# Altium schematic sheet LedBoard.SchDoc
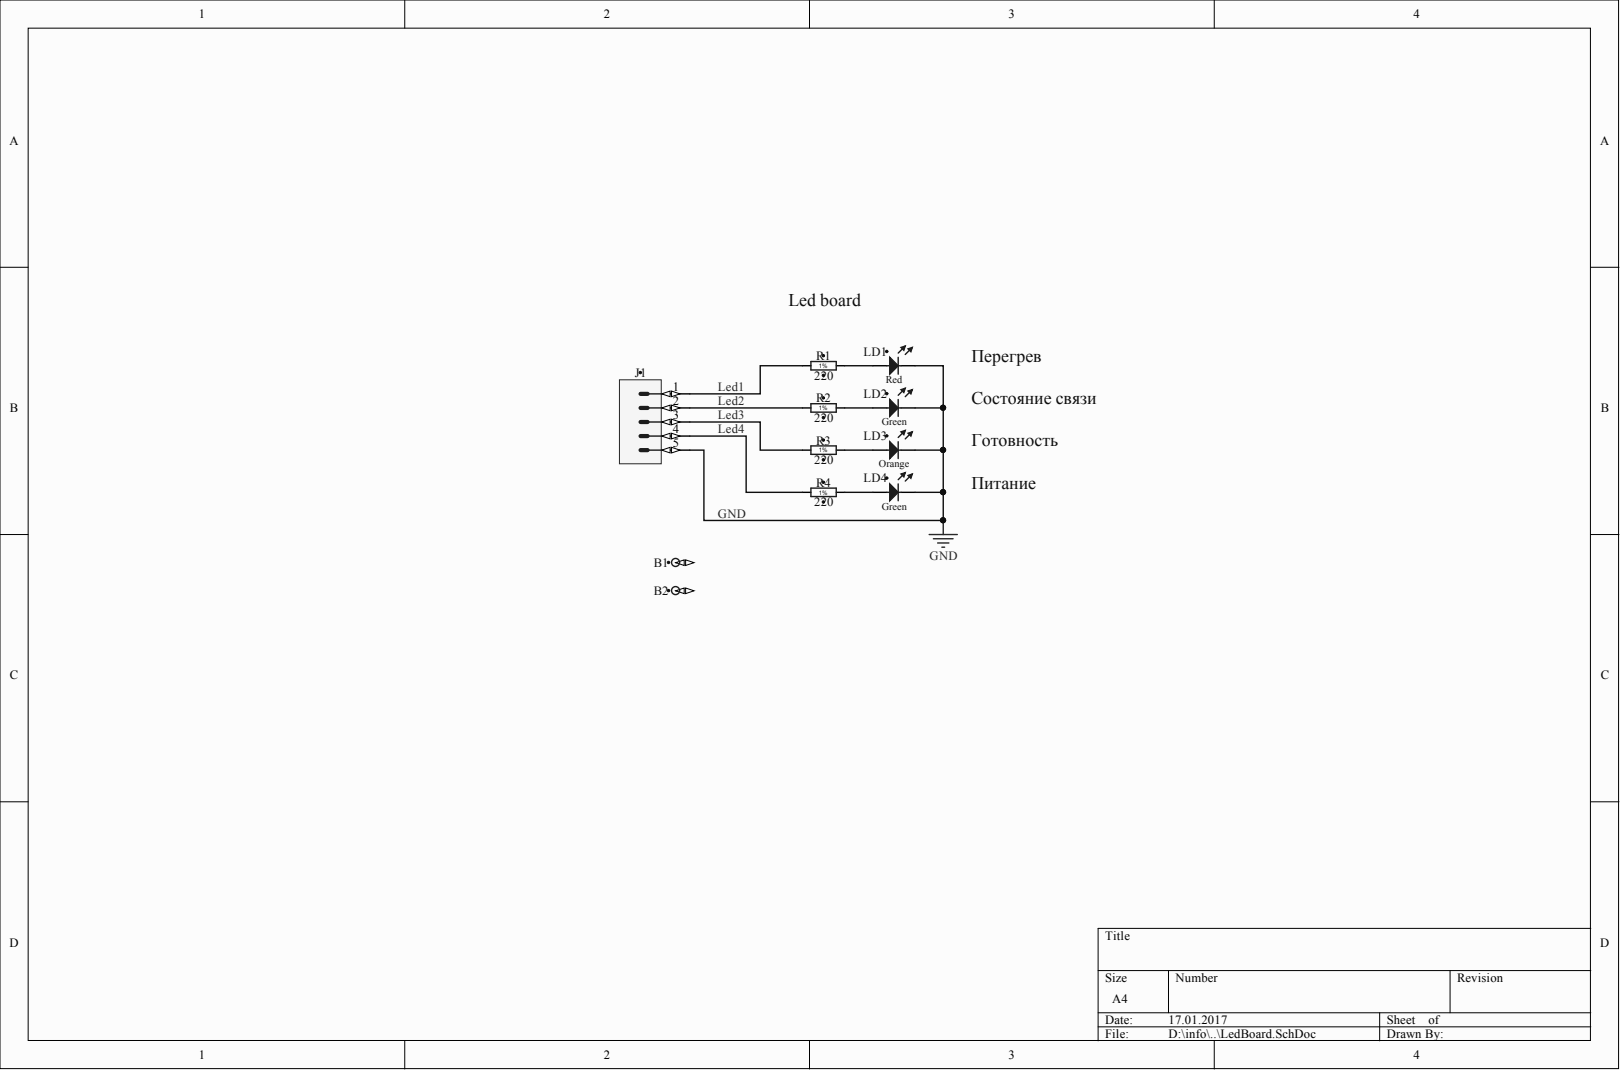
[diagram p0: LedBoard.SchDoc]

## Parts
B1  Non Plated Hole  [MTG300]  (value not drawn)  (no BOM)
B2  Non Plated Hole  [MTG300]  (value not drawn)  (no BOM)
J1  KK®  [MOLEX_22-27-2051]  (value not drawn)
LD1  Super Red  [LED_OSRAM_LSR976]  (value not drawn)
LD2  Green  [LED_OSRAM_LGR971]
LD3  Orange  [LED_OSRAM_LOR976]
LD4  Green  [LED_OSRAM_LGR971]
R1  220  [RESC2012X60N]
R2  220  [RESC2012X60N]
R3  220  [RESC2012X60N]
R4  220  [RESC2012X60N]

## Nets
GND: J1.5 LD1.1 LD2.1 LD3.1 LD4.1
LED1: J1.1 R1.1
LED2: J1.2 R2.1
LED3: J1.3 R3.1
LED4: J1.4 R4.1
NetLD1_2: LD1.2 R1.2
NetLD2_2: LD2.2 R2.2
NetLD3_2: LD3.2 R3.2
NetLD4_2: LD4.2 R4.2

Not connected: B1 1; B2 1

## Library data of the parts
B1 B2 = NON-PLATED_HOLE3.0: Single Terminal Socket; Part Description: Non Plated Through Hole: Hole Dia.=3.0mm; Database Table Name: Pads; Mounted: No; ComponentHeight: 0mm; Component Type: Standard (No BOM); CreateDate: 26.05.2008; Family: Non Plated Hole; LatestRevisionDate: 26.05.2008; Library Name: Eletro-mechanical.DbLib; PackageDescription: Non Plated Through Hole: Hole Dia.=3.0mm; Part Number: NON-PLATED_HOLE3.0; Pin Count: 1; SMD: No; Socket: No; Status: None; Table: Pads; Author: CERN DEM JLC
J1 = MOLEX_22-27-2051: Connector 5 Male; Part Description: 2.54mm (.100") Pitch KK® Wire-to-Board Header, Vertical, with Friction Lock, 5 Circuits, Tin (Sn) Plating; Database Table Name: MOLEX; ComponentHeight: 11.7mm; Component Kind: Standard; Component Type: Standard; CreateDate: 04.12.2008; Family: KK®; HelpURL: \\cern.ch\dfs\Applications\Altium\Datasheets\MOLEX_22-27-2051.pdf; LatestRevisionDate: 04.12.2008; Library Name: Connectors.DbLib; Manufacturer: MOLEX; Manufacturer Part Number: 22-27-2051; Mounted: Yes; Part Number: MOLEX_22-27-2051; Pin Count: 5; SMD: No; Socket: No; Status: None; Table: MOLEX; Author: CERN DEM JLC
LD1 = LED_OSRAM_LSR976: LED Red 1C 2A; Manufacturer: OSRAM; Manufacturer Part Number: LSR976; Part Description: Surface Mount Super Red LED (0805 Case); Database Table Name: LEDs & Displays; Case: 0805; Color: Super Red; ComponentHeight: 0.9mm; Component Kind: Standard; Component Type: Standard; CreateDate: 18.05.2010; HelpURL: \\cern.ch\dfs\Applications\Altium\Datasheets\LED_OSRAM_LSR976.pdf; LatestRevisionDate: 18.05.2010; Library Name: ICs And Semiconductors.DbLib; Mounted: Yes; PackageDescription: Super Red Chip LED, 2-Leads, Body 2 x1.25mm, IPC Medium Density; Part Number: LED_OSRAM_LSR976; Pin Count: 2; SMD: Yes; Socket: No; Table: LEDs & Displays; Author: CERN DEM JLC
LD2 LD4 = LED_OSRAM_LGR971: LED Green 1C 2A; Manufacturer: OSRAM; Manufacturer Part Number: LGR971; Part Description: Surface Mount Green LED (0805 Case); Database Table Name: LEDs & Displays; Case: 0805; Color: Green; ComponentHeight: 0.9mm; Component Kind: Standard; Component Type: Standard; CreateDate: 28.01.2009; HelpURL: \\cern.ch\dfs\Applications\Altium\Datasheets\LED_OSRAM_LGR971.pdf; LatestRevisionDate: 17.03.2010; Library Name: ICs And Semiconductors.DbLib; Mounted: Yes; PackageDescription: Green Chip LED, 2-Leads, Body 2.0x1.25mm, IPC Medium Density; Part Number: LED_OSRAM_LGR971; Pin Count: 2; SMD: Yes; Socket: No; Table: LEDs & Displays; Author: CERN DEM JLC
LD3 = LED_OSRAM_LOR976: LED Orange 1C 2A; Manufacturer: OSRAM; Manufacturer Part Number: LOR976; Part Description: Surface Mount Orange LED (0805 Case); Database Table Name: LEDs & Displays; Case: 0805; Color: Orange; ComponentHeight: 0.9mm; Component Kind: Standard; Component Type: Standard; CreateDate: 18.05.2010; HelpURL: \\cern.ch\dfs\Applications\Altium\Datasheets\LED_OSRAM_LOR976.pdf; LatestRevisionDate: 18.05.2010; Library Name: ICs And Semiconductors.DbLib; Mounted: Yes; PackageDescription: Orange Chip LED, 2-Leads, Body 2 x1.25mm, IPC Medium Density; Part Number: LED_OSRAM_LOR976; Pin Count: 2; SMD: Yes; Socket: No; Table: LEDs & Displays; Author: CERN DEM JLC
R1-R4 = R0805_220R_1%_0.125W_100PPM: Resistor - 1%; Manufacturer: GENERIC; Manufacturer1 Part Number: NRC10F2200TRF; Part Description: General Purpose Thick Film Chip Resistor; Database Table Name: Resistors SMD; Power: 0.125W; TC: ±100ppm/°C; Case: 0805; Component Kind: Standard; Component Type: Standard; CreateDate: 03.12.2007; HelpURL: \\cern.ch\dfs\Applications\Altium\Datasheets\R0805_NIC_NRC.pdf; LatestRevisionDate: 13.03.2008; Library Name: Resistors.DbLib; Manufacturer1 ComponentHeight: 0.6mm; Manufacturer1 Example: NIC COMPONENT; Manufacturer Part Number: R0805_220R_1%_0.125W_100PPM; Mounted: Yes; Part Number: R0805_220R_1%_0.125W_100PPM; Pin Count: 2; SMD: Yes; Socket: No; Status: Preferred; Table: Resistors SMD; Tolerance: ±1%; Value: 220; Author: CERN DEM JLC
